# Altium schematic sheet LEDs_Sheet.SchDoc
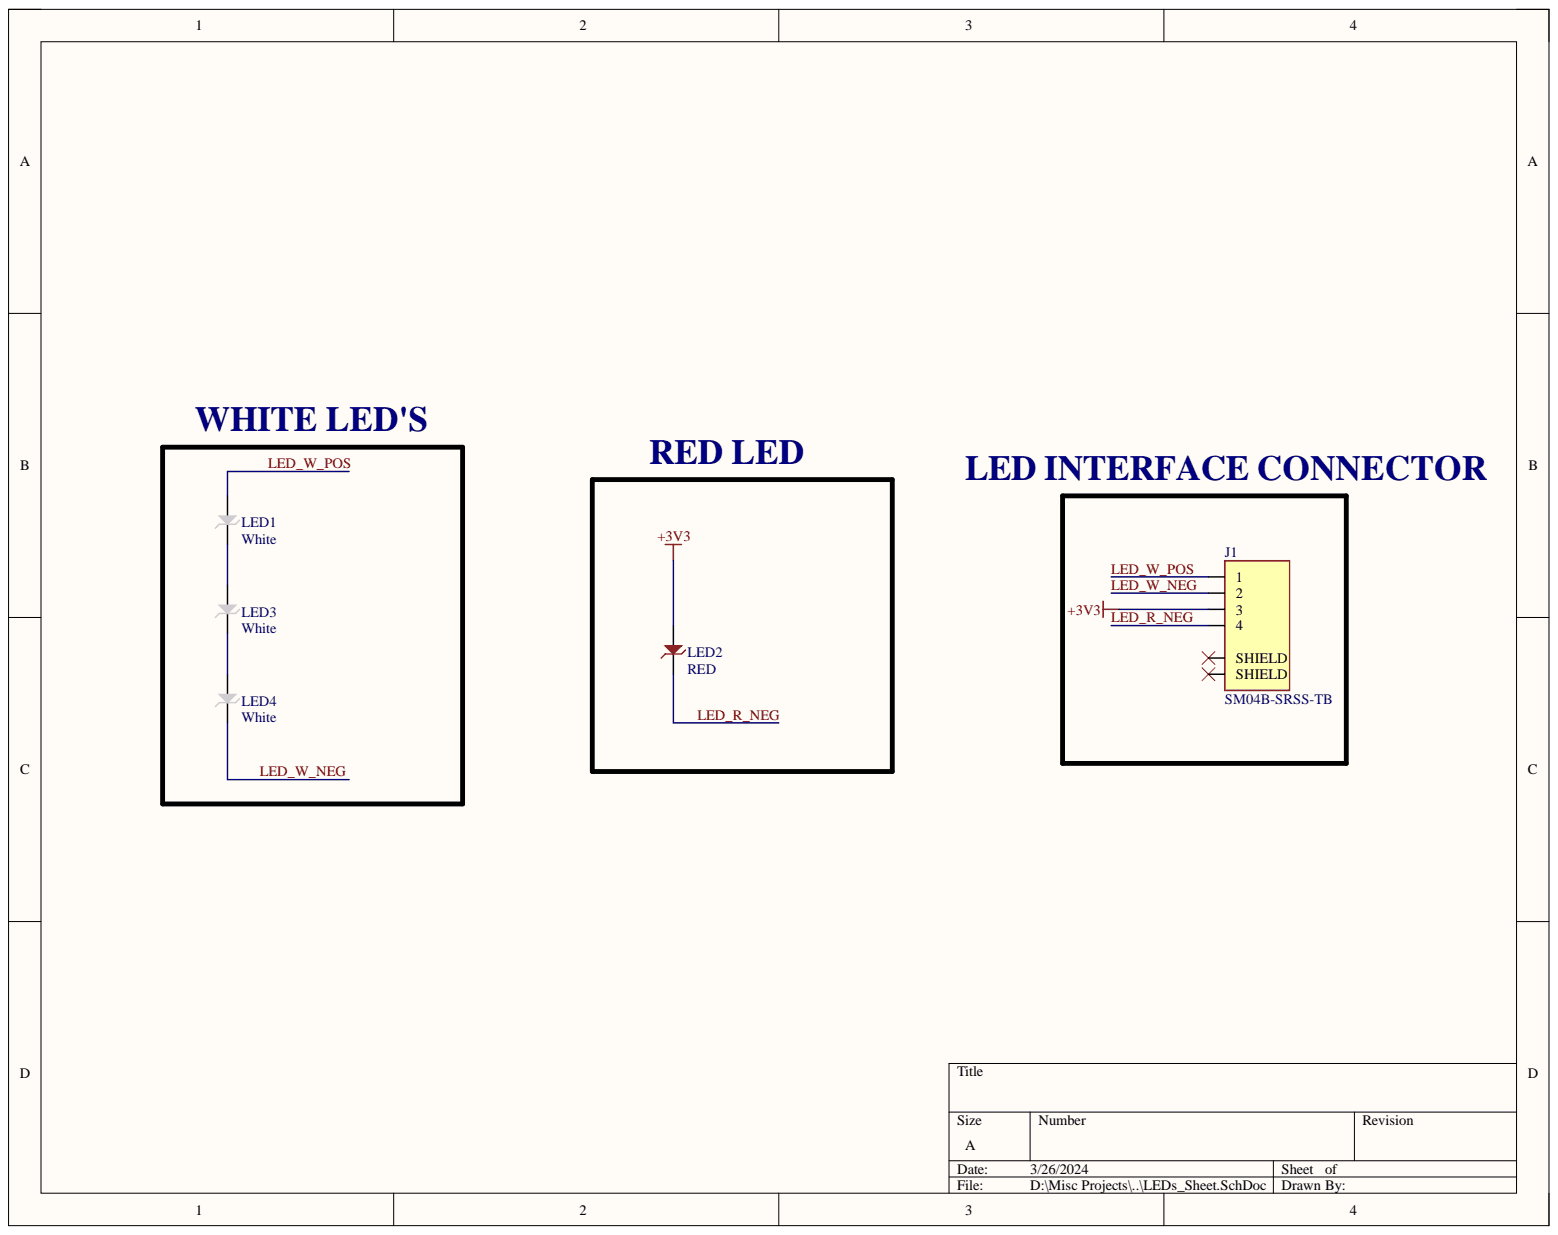
[diagram p0: LEDs_Sheet.SchDoc]

## Parts
J1  SM04B-SRSS-TB  [JST_SM04B-SRSS-TB(LF)(SN)]
LED1  White  [LED_SPHRD2L3DH20E7W41J_SAM]
LED2  RED  [LED_SPHRD2L3DH20E7W41J_SAM]
LED3  White  [LED_SPHRD2L3DH20E7W41J_SAM]
LED4  White  [LED_SPHRD2L3DH20E7W41J_SAM]

## Nets
+3V3: J1.3 LED2.1
LED_R_NEG: J1.4 LED2.2
LED_W_NEG: J1.2 LED4.2
LED_W_POS: J1.1 LED1.1
NetLED1_2: LED1.2 LED3.1
NetLED3_2: LED3.2 LED4.1

Not connected: J1 S1(SHIELD) S2(SHIELD)

## Library data of the parts
J1 = SM04B-SRSS-TB: Connector Header Surface Mount, Right Angle 4 position 0.039" (1.00mm); Manufacturer: JST Sales America Inc.; Component Kind: Standard; ComponentLink1Description: Digikey Link; ComponentLink1URL: https://www.digikey.com/en/products/detail/jst-sales-america-inc/SM04B-SRSS-TB/926710; Digikey Part Number: 455-SM04B-SRSS-TBDKR-ND; Item Type: Connector; Library Name: LED-Driver-Library.IntLib; Manufacturer Part Number: SM04B-SRSS-TB; Package: SMD
LED1 LED3 LED4 = SPHWH2L3D30CD4QTP3: LED Lighting LH351B White, Cool 5700K 2.8V 350mA 120° 1414 (3535 Metric); Manufacturer: Samsung Semiconductor, Inc.; Component Kind: Standard; ComponentLink1Description: Digikey Link; ComponentLink1URL: https://www.digikey.com/en/products/detail/samsung-semiconductor-inc/SPHWH2L3D30CD4QTP3/6569391; Digikey Part Number: 1510-2143-6-ND; Item Type: LED; Library Name: LED-Driver-Library.IntLib; Manufacturer Part Number: SPHWH2L3D30CD4QTP3; Package: 0.138" L x 0.138" W (3.50mm x 3.50mm)
LED2 = SPHRD2L3DH20E7W41J: LED Lighting Color LH351H Red 660nm (650nm ~ 670nm); Manufacturer: Samsung Semiconductor, Inc.; Component Kind: Standard; ComponentLink1Description: Digikey Link; ComponentLink1URL: https://www.digikey.com/en/products/detail/samsung-semiconductor-inc/SPHRD2L3DH20E7W41J/13617167?s=N4IgTCBcDaIMoAUASAlAImAMgZjUsADAKIDsA6gCwCMAUiALoC%2BQA; Digikey Part Number: 1510-SPHRD2L3DH20E7W41JDKR-ND; Item Type: LED; Library Name: LED-Driver-Library.IntLib; Manufacturer Part Number: SPHRD2L3DH20E7W41J; Package: 0.138" L x 0.138" W (3.50mm x 3.50mm)
